# Altium schematic sheet GPSSchRGB.SchDoc
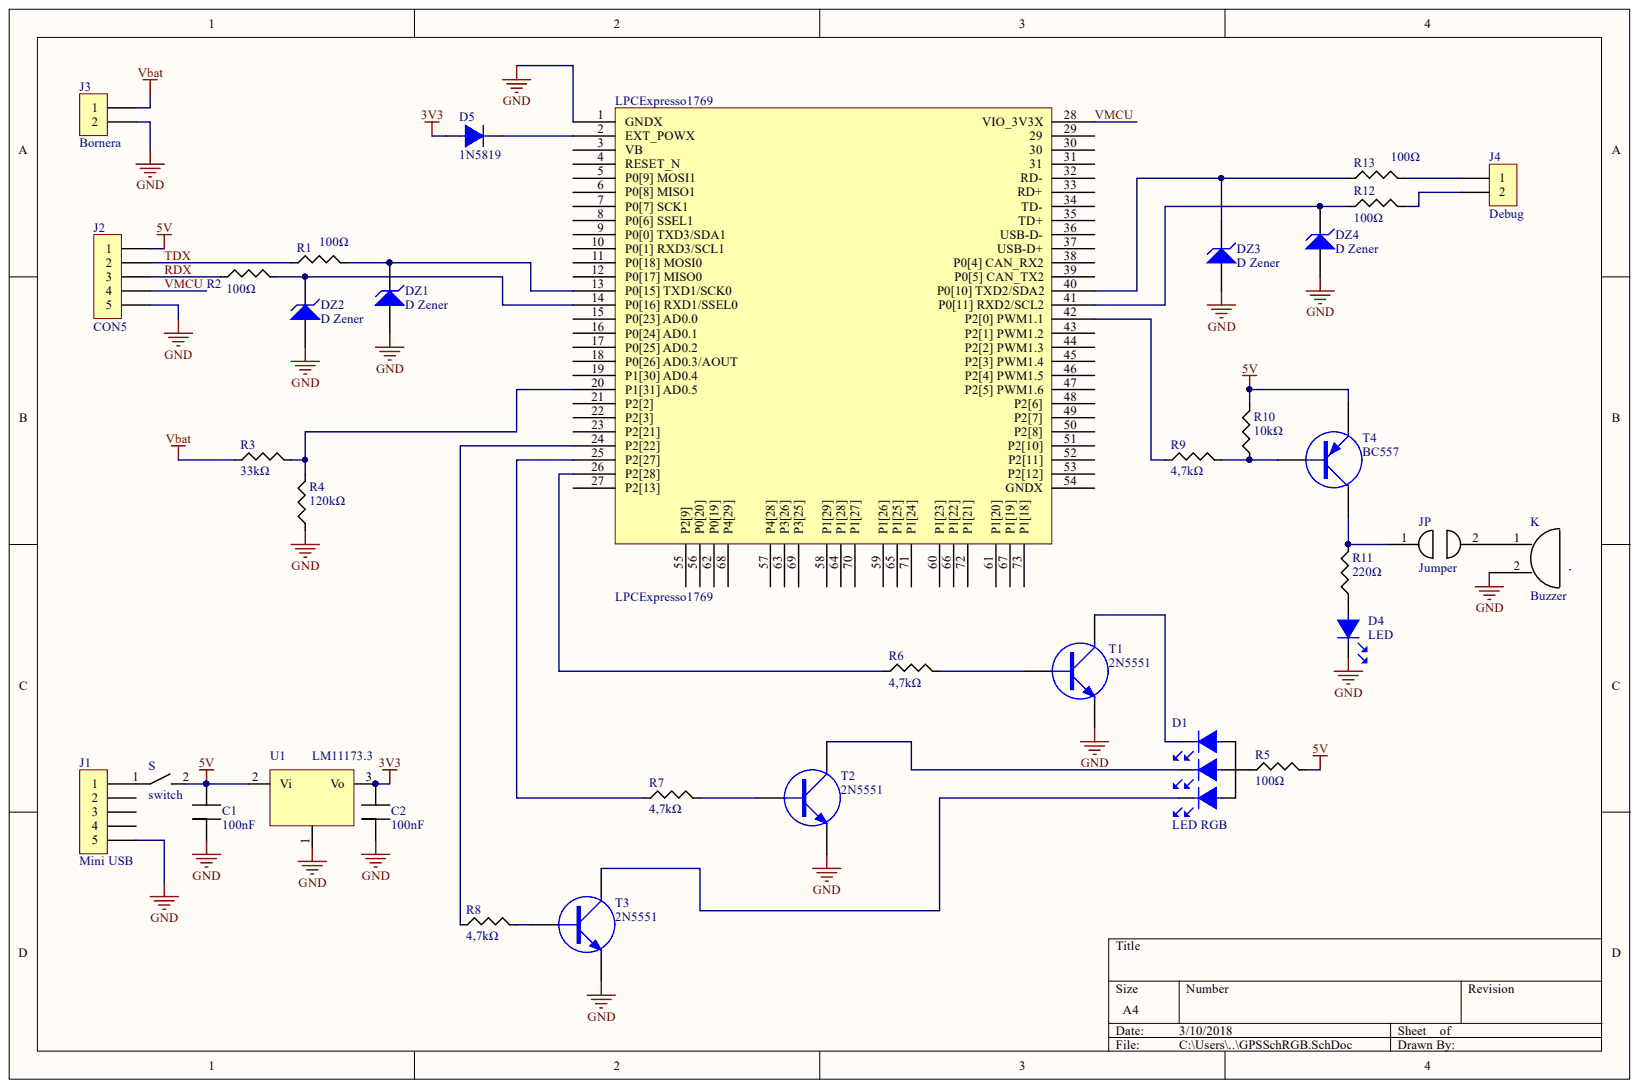
[diagram p0: GPSSchRGB.SchDoc]

## Parts
C1  100nF  [DO41-7.6_1N5819]
C2  100nF  [DO41-7.6_1N5819]
D1  LED RGB  [LED RGB]
D4  LED  [LED]
D5  1N5819  [DO41-7.6_1N5819]
DZ1  D Zener  [DO41-7.6_1N5819]
DZ2  D Zener  [DO41-7.6_1N5819]
DZ3  D Zener  [DO41-7.6_1N5819]
DZ4  D Zener  [DO41-7.6_1N5819]
J1  Mini USB  [Conector 5P]
J2  CON5  [Conector 5P]
J3  Bornera  [Bornera_2]
J4  Debug  [Bornera_2]
JP  Jumper  [Bornera]
K  Buzzer  [CUI_CMT-6635C-030_CMT-6635C-030(Primary)]
LPCExpresso1769  LPCExpresso1769  [LPC_1769]
R1  100Ω  [R_1206]
R2  100Ω  [R_1206]
R3  33kΩ  [R_1206]
R4  120kΩ  [R_1206]
R5  100Ω  [R_1206]
R6  4,7kΩ  [R_1206]
R7  4,7kΩ  [R_1206]
R8  4,7kΩ  [R_1206]
R9  4,7kΩ  [R_1206]
R10  10kΩ  [R_1206]
R11  220Ω  [R_1206]
R12  100Ω  [R_1206]
R13  100Ω  [R_1206]
S  switch  [Switch]
T1  2N5551  [TO92]
T2  2N5551  [TO92]
T3  2N5551  [TO92]
T4  BC557  [TO92]
U1  LM11173.3  [TO254P470X1016X2222-3P]

## Nets
3V3: C2.2 D5.1 U1.3
5V: C1.2 J2.1 R5.2 R10.2 S.2 T4.3 U1.2
GND: C1.1 C2.1 D4.2 DZ1.1 DZ2.1 DZ3.1 DZ4.1 J1.5 J2.5 J3.2 K.2 LPCExpresso1769.1(GNDX) R4.1 T1.2 T2.2 T3.2 U1.1
VBAT: J3.1 R3.1
RDX: J2.3 R2.1
TDX: J2.2 R1.1
VMCU: J2.4 LPCExpresso1769.28(VIO_3V3X)
NetD1_1: D1.1 T3.3
NetD1_2: D1.2 T2.3
NetD1_3: D1.3 T1.3
NetD1_4: D1.4 R5.1
NetD4_1: D4.1 R11.1
NetD5_2: D5.2 LPCExpresso1769.2(EXT_POWX)
NetDZ1_2: DZ1.2 LPCExpresso1769.13(P0[15]_TXD1/SCK0) R1.2
NetDZ2_2: DZ2.2 LPCExpresso1769.14(P0[16]_RXD1/SSEL0) R2.2
NetDZ3_2: DZ3.2 LPCExpresso1769.40(P0[10]_TXD2/SDA2) R13.1
NetDZ4_2: DZ4.2 LPCExpresso1769.41(P0[11]_RXD2/SCL2) R12.1
NetJ1_1: J1.1 S.1
NetJ4_1: J4.1 R13.2
NetJ4_2: J4.2 R12.2
NetJP_1: JP.1 R11.2 T4.2
NetJP_2: JP.2 K.1
NetLPCExpresso1769_20: LPCExpresso1769.20(P1[31]_AD0.5) R3.2 R4.2
NetLPCExpresso1769_24: LPCExpresso1769.24(P2[22]) R8.1
NetLPCExpresso1769_25: LPCExpresso1769.25(P2[27]) R7.1
NetLPCExpresso1769_26: LPCExpresso1769.26(P2[28]) R6.1
NetLPCExpresso1769_42: LPCExpresso1769.42(P2[0]_PWM1.1) R9.1
NetR6_2: R6.2 T1.1
NetR7_2: R7.2 T2.1
NetR8_2: R8.2 T3.1
NetR9_2: R9.2 R10.1 T4.1

Not connected: J1 2 3 4; LPCExpresso1769 3(VB) 4(RESET_N) 5(P0[9]_MOSI1) 6(P0[8]_MISO1) 7(P0[7]_SCK1) 8(P0[6]_SSEL1) 9(P0[0]_TXD3/SDA1) 10(P0[1]_RXD3/SCL1) 11(P0[18]_MOSI0) 12(P0[17]_MISO0) 15(P0[23]_AD0.0) 16(P0[24]_AD0.1) 17(P0[25]_AD0.2) 18(P0[26]_AD0.3/AOUT) 19(P1[30]_AD0.4) 21(P2[2]) 22(P2[3]) 23(P2[21]) 27(P2[13]) 29 30 31 32(RD-) 33(RD+) 34(TD-) 35(TD+) 36(USB-D-) 37(USB-D+) 38(P0[4]_CAN_RX2) 39(P0[5]_CAN_TX2) 43(P2[1]_PWM1.2) 44(P2[2]_PWM1.3) 45(P2[3]_PWM1.4) 46(P2[4]_PWM1.5) 47(P2[5]_PWM1.6) 48(P2[6]) 49(P2[7]) 50(P2[8]) 51(P2[10]) 52(P2[11]) 53(P2[12]) 54(GNDX) 55(P2[9]) 56(P0[20]) 57(P4[28]) 58(P1[29]) 59(P1[26]) 60(P1[23]) 61(P1[20]) 62(P0[19]) 63(P3[26]) 64(P1[28]) 65(P1[25]) 66(P1[22]) 67(P1[19]) 68(P4[29]) 69(P3[25]) 70(P1[27]) 71(P1[24]) 72(P1[21]) 73(P1[18])

## Library data of the parts
C1 C2 = Capacitor: Component Kind: Standard; Library Name: LibGps.SchLib
D1 = LED RGB: RGB catodo comun; Component Kind: Standard; Library Name: LibGps.SchLib
D5 = DIODO: Component Kind: Standard; Library Name: LibGps.SchLib
DZ1-DZ4 = D Zener: Zener Diode; Component Kind: Standard; LatestRevisionDate: 17-Jul-2002; LatestRevisionNote: Re-released for DXP Platform.; Library Name: LibGps.SchLib; PackageDescription: Diode, Thru-Hole; 2 Leads; 0.7 in Pin Spacing; PackageReference: DIODE-0.7; Published: 8-Jun-2000; Publisher: Altium Limited
J1 = CON5: Component Kind: Standard; Library Name: LibGps.SchLib
K = Buzzer2: Component Kind: Standard; Library Name: LibGps.SchLib
LPCExpresso1769 = LPCExpresso1769: stick; Component Kind: Standard; Library Name: LibGps.SchLib
R1-R13 = Resistor: Component Kind: Standard; Library Name: LibGps.SchLib
T1-T3 = TRANSISTOR NPN: Component Kind: Standard; Library Name: LibGps.SchLib
T4 = TRANSISTOR PNP: Component Kind: Standard; Library Name: LibGps.SchLib
U1 = LM11173.3: Regulador; Component Kind: Standard; Library Name: LibGps.SchLib
D4 = LED: Component Kind: Standard; Library Name: LibGps.SchLib
J2 = CON5: Component Kind: Standard; Library Name: LibGps.SchLib
J3 = Bornera: Component Kind: Standard; Library Name: LibGps.SchLib
J4 = Debug: Component Kind: Standard; Library Name: LibGps.SchLib
JP = Jumper: Component Kind: Standard; Library Name: LibGps.SchLib
S = switch: Component Kind: Standard; Library Name: LibGps.SchLib
